# Altium schematic sheet 0.42-ESP32C6.SchDoc
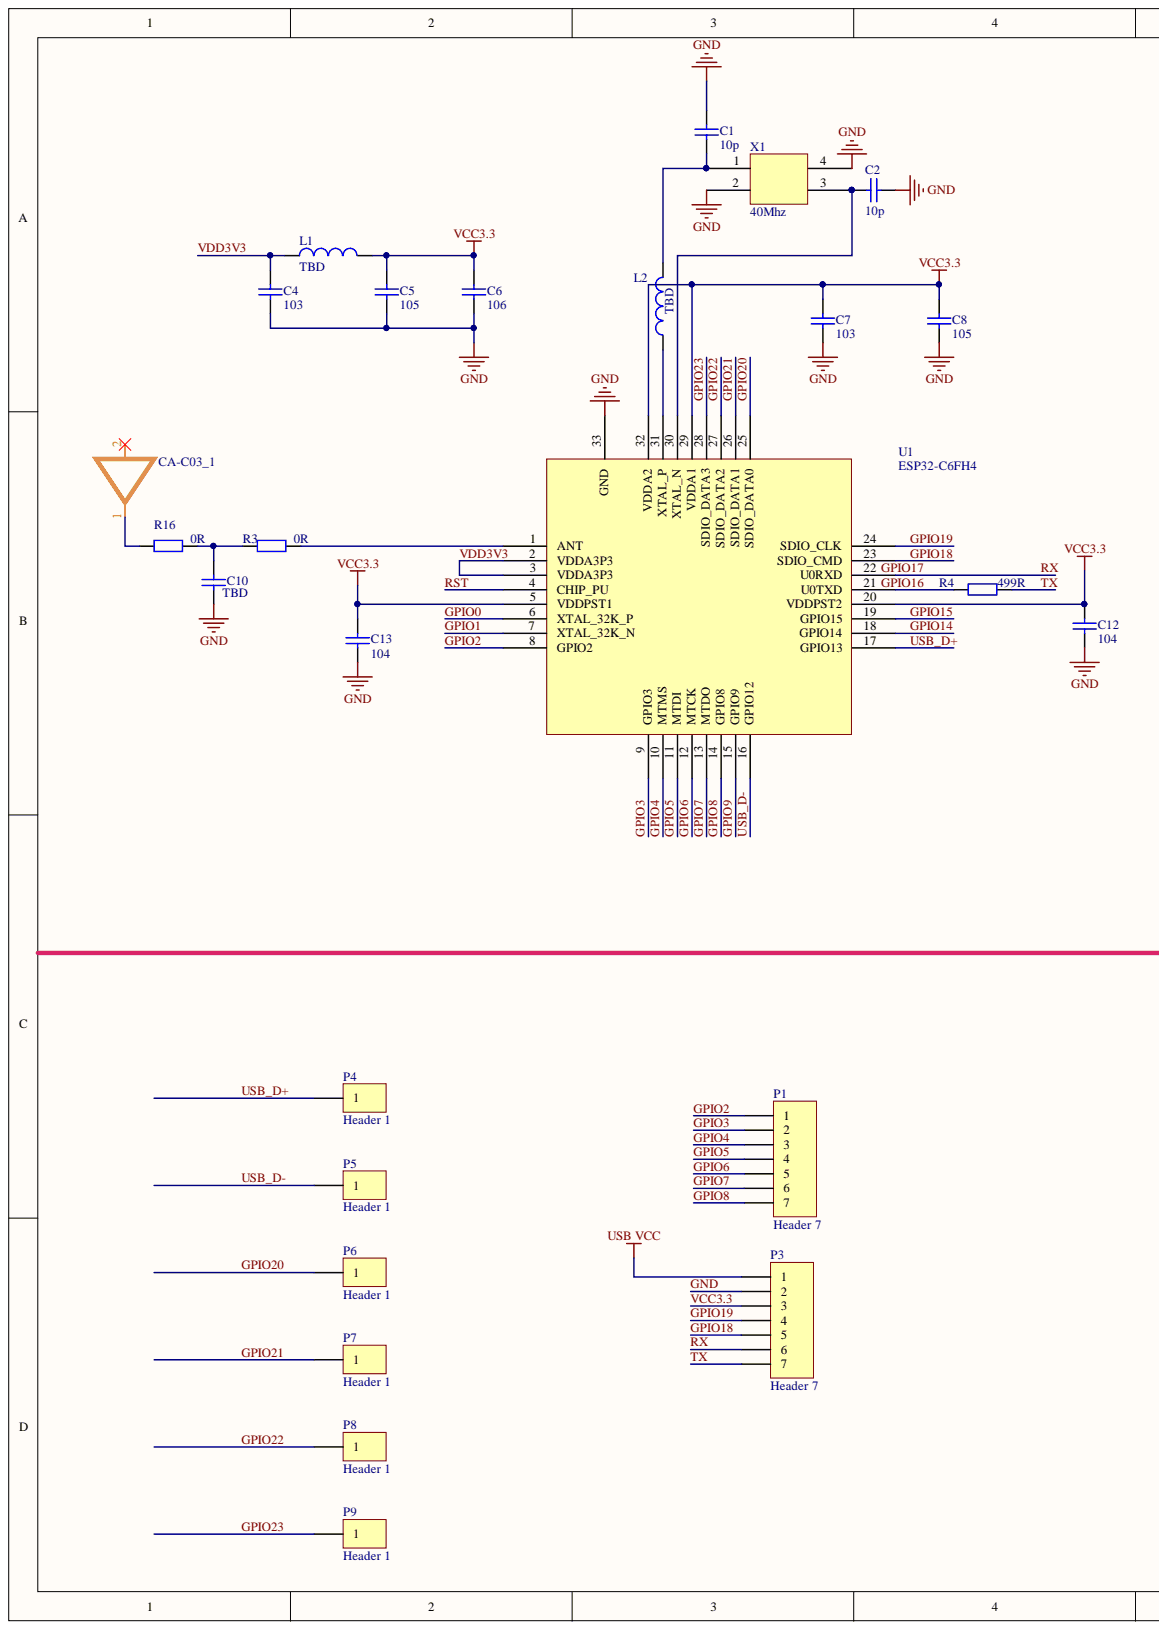
[diagram p0: 0.42-ESP32C6.SchDoc, left]
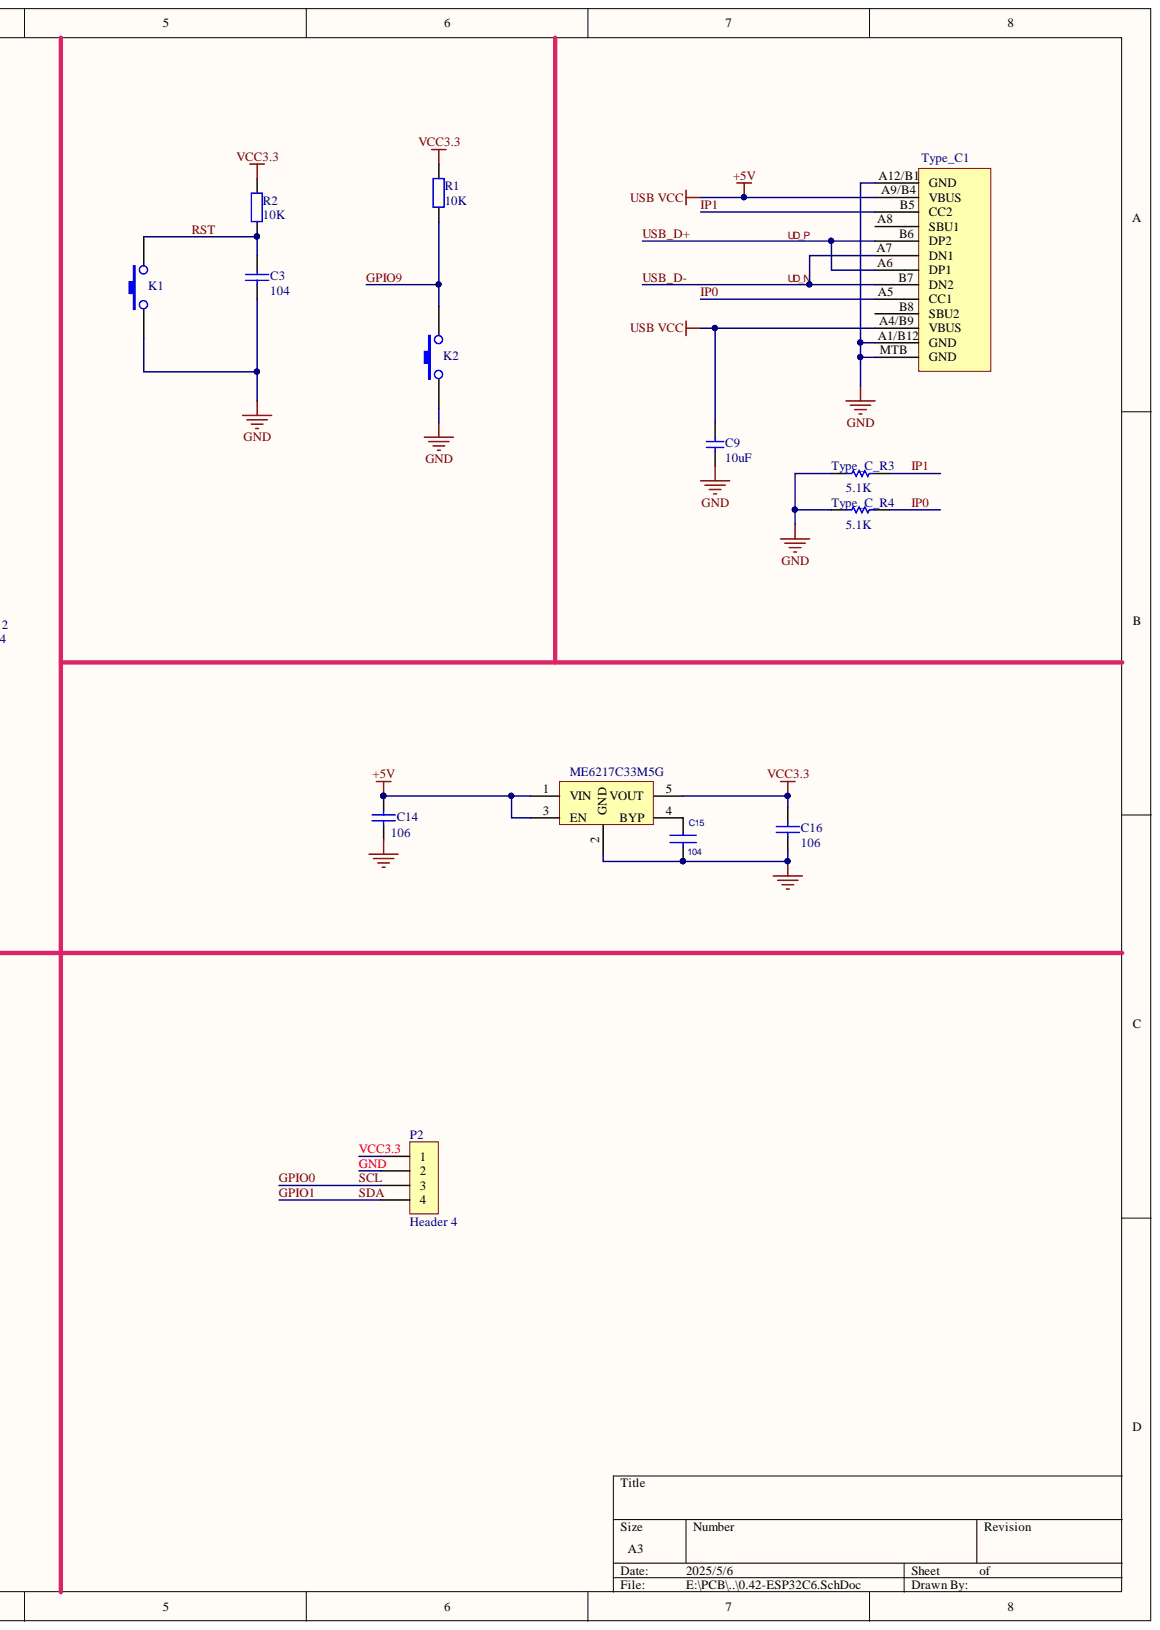
[diagram p1: 0.42-ESP32C6.SchDoc, right]

## Parts
C1  10p  [C0201]
C2  10p  [C0201]
C3  104  [C0201]
C4  103  [C0201]
C5  105  [C0201]
C6  106  [C0402]
C7  103  [C0201]
C8  105  [C0201]
C9  10uF  [C0402]
C10  TBD  [C0201]
C12  104  [C0201]
C13  104  [C0201]
C14  106  [C0402]
C15  104  [C0201]
C16  106  [C0402]
CA-C03_1  CA-C03  [ANT_RAINSUN]
K1  KEY2  [SW-SMD_L3.9-W3.0-P4.45]  (value not drawn)
K2  KEY2  [SW-SMD_L3.9-W3.0-P4.45]  (value not drawn)
L1  value TBD  [R0201]  (hidden comment: Inductor)
L2  value TBD  [R0201]  (hidden comment: Inductor)
ME6217C33M5G  CAT6219  [TPS61040]  (value not drawn)
P1  Header 7  [SIP7-1.2]
P2  Header 4  [4PIN-2]
P3  Header 7  [SIP7-1.2]
P4  Header 1  [Testpoint]
P5  Header 1  [Testpoint]
P6  Header 1  [Testpoint]
P7  Header 1  [Testpoint]
P8  Header 1  [Testpoint]
P9  Header 1  [Testpoint]
R1  value 10K  [R0201]  (hidden comment: Res2)
R2  value 10K  [R0201]  (hidden comment: Res2)
R3  value 0R  [R0201]  (hidden comment: 10R)
R4  value 499R  [R0201]  (hidden comment: Res2)
R16  value 0R  [R0201]  (hidden comment: 10R)
Type_C1  USB_TYPE_C_16PIN_A  [USB_TYPE_C_16PIN_A]  (value not drawn)
Type_C_R3  5.1K  [R0201]
Type_C_R4  5.1K  [R0201]
U1  ESP32-C6FH4  [QFN-32_L5.0-W5.0-P0.50-TL-EP3.7]
X1  40Mhz  [SMD2016]

## Nets
+5V: C9.1 C14.1 ME6217C33M5G.1(VIN) ME6217C33M5G.3(EN) P3.1 Type_C1.A4/B9(VBUS) Type_C1.A9/B4(VBUS)
GND: C1.1 C2.1 C3.1 C4.1 C5.1 C6.1 C7.1 C8.1 C9.2 C10.1 C12.1 C13.1 C14.2 C15.2 C16.2 K1.1 K2.1 ME6217C33M5G.2(GND) P2.2 P3.2 Type_C1.A1/B12(GND) Type_C1.A12/B1(GND) Type_C1.MTB(GND) Type_C_R3.1 Type_C_R4.1 U1.33(GND) X1.2 X1.4
GPIO0: P2.3 U1.6(XTAL_32K_P)
GPIO1: P2.4 U1.7(XTAL_32K_N)
GPIO2: P1.1 U1.8(GPIO2)
GPIO3: P1.2 U1.9(GPIO3)
GPIO4: P1.3 U1.10(MTMS)
GPIO5: P1.4 U1.11(MTDI)
GPIO6: P1.5 U1.12(MTCK)
GPIO7: P1.6 U1.13(MTDO)
GPIO8: P1.7 U1.14(GPIO8)
GPIO9: K2.2 R1.2 U1.15(GPIO9)
GPIO14: U1.18(GPIO14)
GPIO15: U1.19(GPIO15)
GPIO18: P3.5 U1.23(SDIO_CMD)
GPIO19: P3.4 U1.24(SDIO_CLK)
GPIO20: P6.1 U1.25(SDIO_DATA0)
GPIO21: P7.1 U1.26(SDIO_DATA1)
GPIO22: P8.1 U1.27(SDIO_DATA2)
GPIO23: P9.1 U1.28(SDIO_DATA3)
IP0: Type_C1.A5(CC1) Type_C_R4.2
IP1: Type_C1.B5(CC2) Type_C_R3.2
RST: C3.2 K1.2 R2.2 U1.4(CHIP_PU)
RX: P3.6 U1.22(U0RXD)
TX: P3.7 R4.2
UD_N: P5.1 Type_C1.A7(DN1) Type_C1.B7(DN2) U1.16(GPIO12)
UD_P: P4.1 Type_C1.A6(DP1) Type_C1.B6(DP2) U1.17(GPIO13)
VCC3.3: C5.2 C6.2 C7.2 C8.2 C12.2 C13.2 C16.1 L1.2 ME6217C33M5G.5(VOUT) P2.1 P3.3 R1.1 R2.1 U1.5(VDDPST1) U1.20(VDDPST2) U1.29(VDDA1) U1.32(VDDA2)
VDD3V3: C4.2 L1.1 U1.2(VDDA3P3) U1.3(VDDA3P3)
NetC1_2: C1.2 L2.2 X1.1
NetC2_2: C2.2 U1.30(XTAL_N) X1.3
NetC10_2: C10.2 R3.2 R16.1
NetC15_1: C15.1 ME6217C33M5G.4(BYP)
NetCA-C03_1_1: CA-C03_1.1 R16.2
NetL2_1: L2.1 U1.31(XTAL_P)
NetR3_1: R3.1 U1.1(ANT)
NetR4_1: R4.1 U1.21(U0TXD)
GPIO16:
GPIO17:

Not connected: CA-C03_1 2; Type_C1 A8(SBU1) B8(SBU2)

## Library data of the parts
C1-C8 C10 C12 C13 = Cap: Capacitor; Value: 100pF; Component Kind: Standard; Library Name: zz-houxin.SchLib
C9 = Cap1: Capacitor; Manufacturer: SAMSUNG(三星); Rated Voltage: 6.3V; Tolerance: ±20%; Component Kind: Standard; Library Name: Waveshare Devices.IntLib
C14 C16 = Cap: Capacitor; Value: 104; Component Kind: Standard; Library Name: zz.SchLib
C15 = CAP NP_Dup4: tolerance: 10%; Component Kind: Standard
L1 L2 = Inductor: Code_IEC: 1005; Code_JEITA: 0402; Component Kind: Standard; Description: Inductor; LatestRevisionDate: 29-May-2009; LatestRevisionNote: IPC-7351 Footprint Added.; Library Name: Miscellaneous Devices.IntLib; PackageDescription: Chip Inductor; PackageReference: 0402-A; Published: 8-Jun-2000; Publisher: Altium Limited
P1 P3 = Header 7: Header, 7-Pin; Component Kind: Standard; Library Name: zz.SchLib
P2 = Header 4: Header, 4-Pin; Component Kind: Standard; LatestRevisionDate: 17-Jul-2002; LatestRevisionNote: Re-released for DXP Platform.; Library Name: zz.SchLib; Publisher: Altium Limited
P4-P9 = Header 1: Header, 2-Pin; Component Kind: Standard; LatestRevisionDate: 17-Jul-2002; LatestRevisionNote: Re-released for DXP Platform.; Library Name: zz.SchLib; Publisher: Altium Limited
R1-R4 R16 = Res2: Resistor; Component Kind: Standard; LatestRevisionDate: 17-Jul-2002; LatestRevisionNote: Re-released for DXP Platform.; Library Name: Miscellaneous Devices.IntLib; PackageDescription: Axial Device, Thru-Hole; 2 Leads; 0.4 in Pin Spacing; PackageReference: AXIAL-0.4; Published: 8-Jun-2000; Publisher: Altium Limited
Type_C_R3 Type_C_R4 = Res1: Resistor; Value: 1K; Component Kind: Standard; LatestRevisionDate: 17-Jul-2002; LatestRevisionNote: Re-released for DXP Platform.; Library Name: WS_protel_lib.SchLib; PackageReference: AXIAL-0.3; Published: 8-Jun-2000; Publisher: Altium Limited
X1 = XTAL-3225: Component Kind: Standard; Library Name: zz.SchLib
CA-C03_1 = CA-C03: Component Kind: Standard; Library Name: 0.42-ESP32C6.SchLib
K1 K2 = KEY2: Component Kind: Standard; Library Name: zz.SchLib
ME6217C33M5G = CAT6219: Component Kind: Standard; Library Name: zz.SchLib
Type_C1 = USB_TYPE_C_16PIN_A: Component Kind: Standard; Library Name: Waveshare Devices.IntLib
U1 = ESP32-C6FH4: Component Kind: Standard; Library Name: 0.42-ESP32C6.SchLib
